# Altium schematic sheet PV_MotorIO.SchDoc
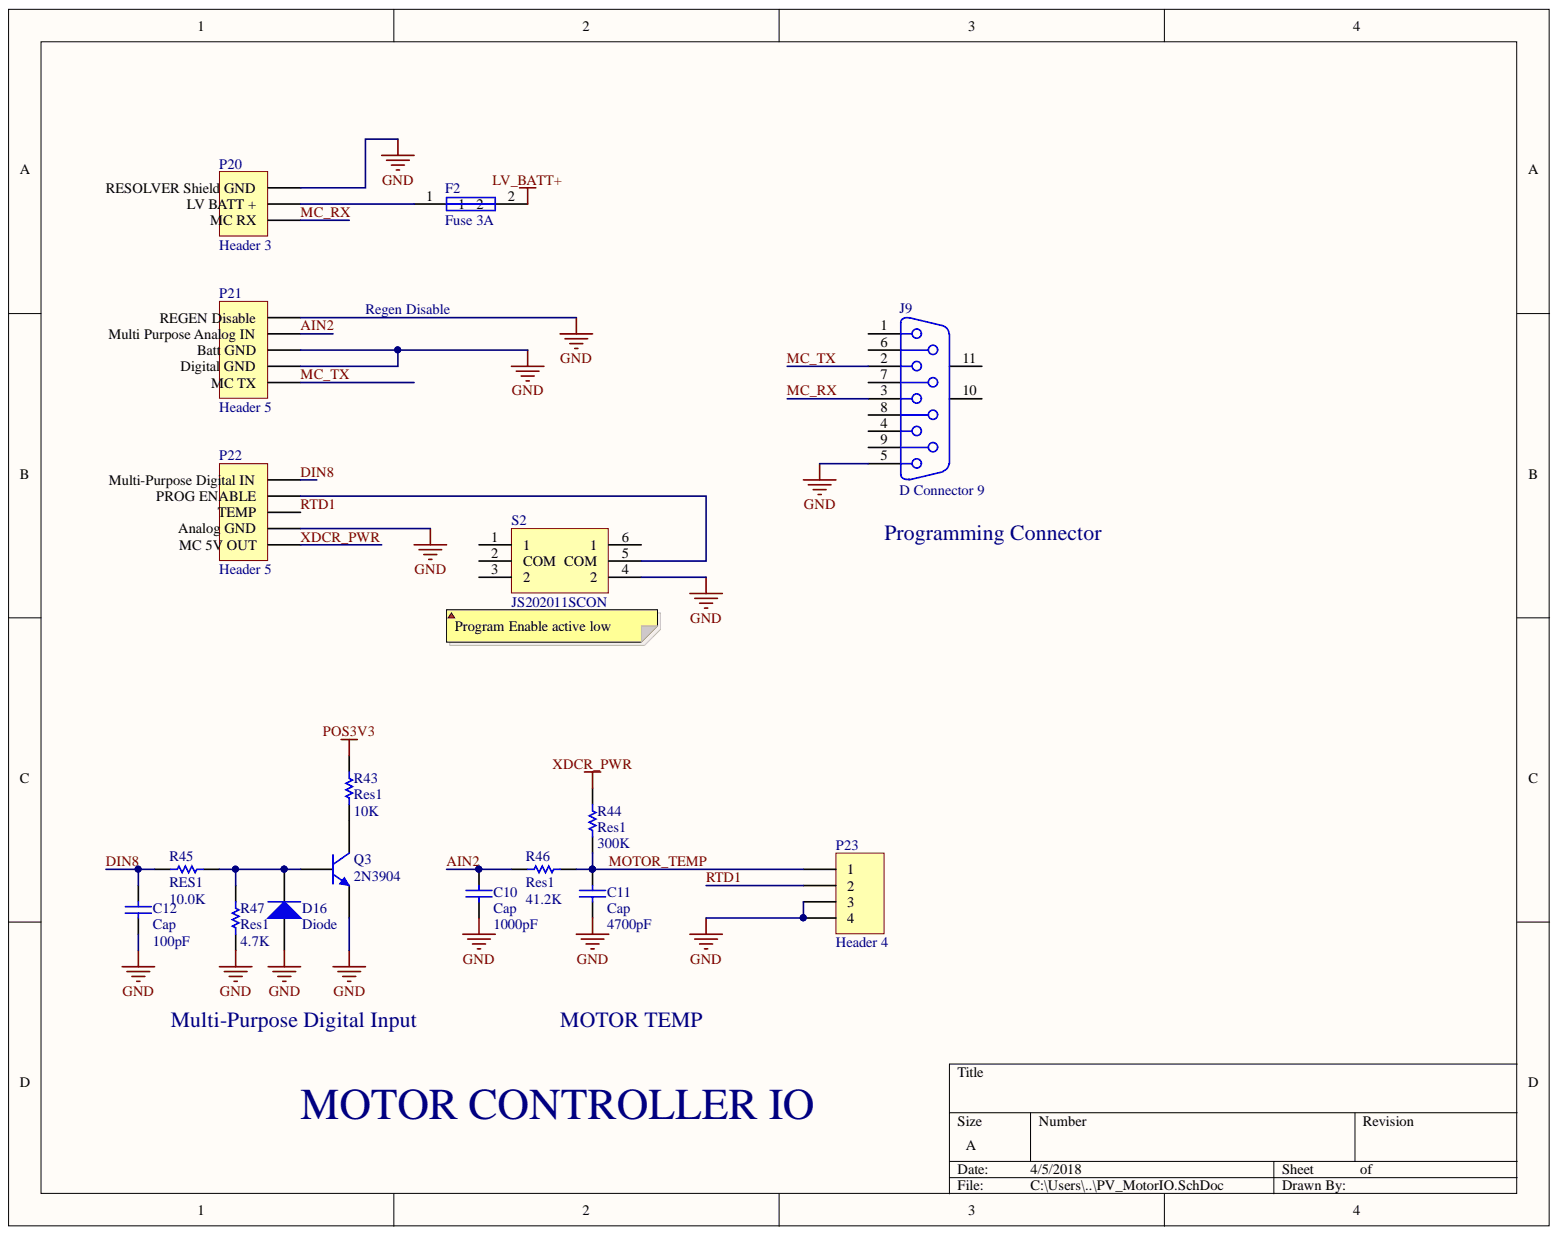
[diagram p0: PV_MotorIO.SchDoc]

## Parts
C10  Cap  value 1000pF  [1206]
C11  Cap  value 4700pF  [1206]
C12  Cap  value 100pF  [1206]
D16  Diode  [1206 DIODE]
F2  Fuse 3A  [1A FUSE HOLDER]
J9  D Connector 9  [DSUB1.385-2H9]
P20  Header 3  [3POS TERM BLOCK]
P21  Header 5  [5POS TERM BLOCK]
P22  Header 5  [5POS TERM BLOCK]
P23  Header 4  [4POS TERM BLOCK]
Q3  2N3904  [BJT]
R43  Res1  value 10K  [1206]
R44  Res1  value 300K  [1206]
R45  RES1  value 10.0K  [1206]
R46  Res1  value 41.2K  [1206]
R47  Res1  value 4.7K  [1206]
S2  JS202011SCON  [JS202011SCON]

## Nets
GND: C10.1 C11.1 C12.1 D16.1 J9.5 P20.3 P21.2(GND) P21.3(GND) P21.5 P22.2(GND) P23.3 P23.4 Q3.1 R47.1 S2.4
LV_BATT+: F2.2
POS3V3: R43.2
AIN2: C10.2 P21.4(IN) R46.2
DIN8: C12.2 P22.5(IN) R45.1
MC_RX: J9.3 P20.1
MC_TX: J9.2 P21.1(TX)
MOTOR_TEMP: C11.2 P23.1 R44.1 R46.1
RTD1: P22.3(TEMP) P23.2
XDCR_PWR: P22.1(OUT) R44.2
NetD16_2: D16.2 Q3.2 R45.2 R47.2
NetF2_1: F2.1 P20.2
NetP22_4: P22.4 S2.5(COM)
NetQ3_3: Q3.3 R43.1

Not connected: J9 1 4 6 7 8 9 10 11; S2 1 2(COM) 3 6

## Library data of the parts
C10-C12 = Cap: Capacitor; Component Kind: Standard; LatestRevisionDate: 17-Jul-2002; LatestRevisionNote: Re-released for DXP Platform.; Library Name: Miscellaneous Devices.IntLib; PackageDescription: Radial Cap, Thru-Hole; 2 Leads; 0.3 in Pin Spacing; PackageReference: RAD-0.3; Published: 8-Jun-2000; Signal Integrity: Cap; Simulation: CAP; Publisher: Altium Limited
D16 = Diode: Default Diode; Code_JEDEC: DO-214-AB; Component Kind: Standard; LatestRevisionDate: 17-Jul-2002; LatestRevisionNote: Re-released for DXP Platform.; Library Name: Miscellaneous Devices.IntLib; PackageDescription: DO-214-AB/SMC; 2 C-Bend Leads; Body 7.9 x 5.9 mm, inc. leads (LxW); PackageReference: SMC; PackageVersion: Sep-1996; Published: 8-Jun-2000; Signal Integrity: Diode; Simulation: DIODE; Publisher: Altium Limited
F2 = Fuse 1: Fuse; Component Kind: Standard; ComponentLink1Description: Digikey- FUSE; ComponentLink1URL: https://www.digikey.com/product-detail/en/littelfuse-inc/0451001.MRL/F2577CT-ND/813055; ComponentLink2Description: Digikey - Holder; ComponentLink2URL: https://www.digikey.com/product-detail/en/littelfuse-inc/0154001.DR/F1222TR-ND/183352; LatestRevisionDate: 17-Jul-2002; LatestRevisionNote: Re-released for DXP Platform.; Library Name: Miscellaneous Devices.IntLib; PackageDescription: Thru-Hole; 2 Leads; PackageReference: PIN-W2/E2.8; Published: 8-Jun-2000; Simulation: FUSE; Publisher: Altium Limited
J9 = D Connector 9: Receptacle Assembly, 9 Position, Right Angle; PackageDocument: O; Positions: 9; Component Kind: Standard; DatasheetDocument: 1-Sep-1999; Gender: Receptacle; LatestRevisionDate: 17-Jul-2002; LatestRevisionNote: Re-released for DXP Platform.; Library Name: Miscellaneous Connectors.IntLib; Orientation: Right Angle; PackageReference: 788750; Published: 15-Jun-2001; Signal Integrity: Connector; Publisher: Altium Limited
P20 = Header 3: Header, 3-Pin; Component Kind: Standard; LatestRevisionDate: 17-Jul-2002; LatestRevisionNote: Re-released for DXP Platform.; Library Name: Miscellaneous Connectors.IntLib; Signal Integrity: Connector; Publisher: Altium Limited
P21 P22 = Header 5: Header, 5-Pin; Component Kind: Standard; LatestRevisionDate: 17-Jul-2002; LatestRevisionNote: Re-released for DXP Platform.; Library Name: Miscellaneous Connectors.IntLib; Signal Integrity: Connector; Publisher: Altium Limited
P23 = Header 4: Header, 4-Pin; Component Kind: Standard; LatestRevisionDate: 17-Jul-2002; LatestRevisionNote: Re-released for DXP Platform.; Library Name: Miscellaneous Connectors.IntLib; Signal Integrity: Connector; Publisher: Altium Limited
Q3 = 2N3904: NPN General Purpose Amplifier; Component Kind: Standard; ComponentLink1Description: Manufacturer Link; ComponentLink1URL: http://www.fairchildsemi.com/; ComponentLink2Description: Datasheet; ComponentLink2URL: http://www.mccsemi.com/up_pdf/2N3904(TO-92).pdf; DatasheetVersion: 1997; LatestRevisionDate: 15-Jan-2003; LatestRevisionNote: PCB Footprint 'BCY-W3/D4.7' replaced; Library Name: Miscellaneous Devices.IntLib; PackageDescription: TO, Flat Index; 3 In-Line, Axial Leads; Body Dia. 4.5mm; Leads 0.51 x 0.48 mm (max); PackageReference: TO-92A; PackageVersion: Sep-1998; Published: 8-Jun-2000; Signal Integrity: 2N3904; Simulation: 2N3904; Publisher: Altium Limited
R43 R44 R47 = Res1: Resistor; Component Kind: Standard; LatestRevisionDate: 17-Jul-2002; LatestRevisionNote: Re-released for DXP Platform.; Library Name: Miscellaneous Devices.IntLib; PackageDescription: Axial Device, Thru-Hole; 2 Leads; 0.3 in Pin Spacing; PackageReference: AXIAL-0.3; Published: 8-Jun-2000; Signal Integrity: Res; Simulation: RESISTOR; Publisher: Altium Limited
R45 = Res1: Resistor; Value: 10.0K; Component Kind: Standard; LatestRevisionDate: 17-Jul-2002; LatestRevisionNote: Re-released for DXP Platform.; Library Name: Miscellaneous Devices.IntLib; PackageDescription: Axial Device, Thru-Hole; 2 Leads; 0.3 in Pin Spacing; PackageReference: AXIAL-0.3; Published: 8-Jun-2000; Signal Integrity: Res; Simulation: RESISTOR; Publisher: Altium Limited
R46 = Res1: Resistor; Value: 41.2K; Component Kind: Standard; LatestRevisionDate: 17-Jul-2002; LatestRevisionNote: Re-released for DXP Platform.; Library Name: Miscellaneous Devices.IntLib; PackageDescription: Axial Device, Thru-Hole; 2 Leads; 0.3 in Pin Spacing; PackageReference: AXIAL-0.3; Published: 8-Jun-2000; Signal Integrity: Res; Simulation: RESISTOR; Publisher: Altium Limited
S2 = JS202011SCON: DPST Switch; Component Kind: Standard; ComponentLink1Description: Digikey; ComponentLink1URL: https://www.digikey.com/product-detail/en/c-k/JS202011SCQN/401-2002-1-ND/1640098; Library Name: ic.SchLib
